# Altium schematic sheet Sheet1.SchDoc(Sheet1)
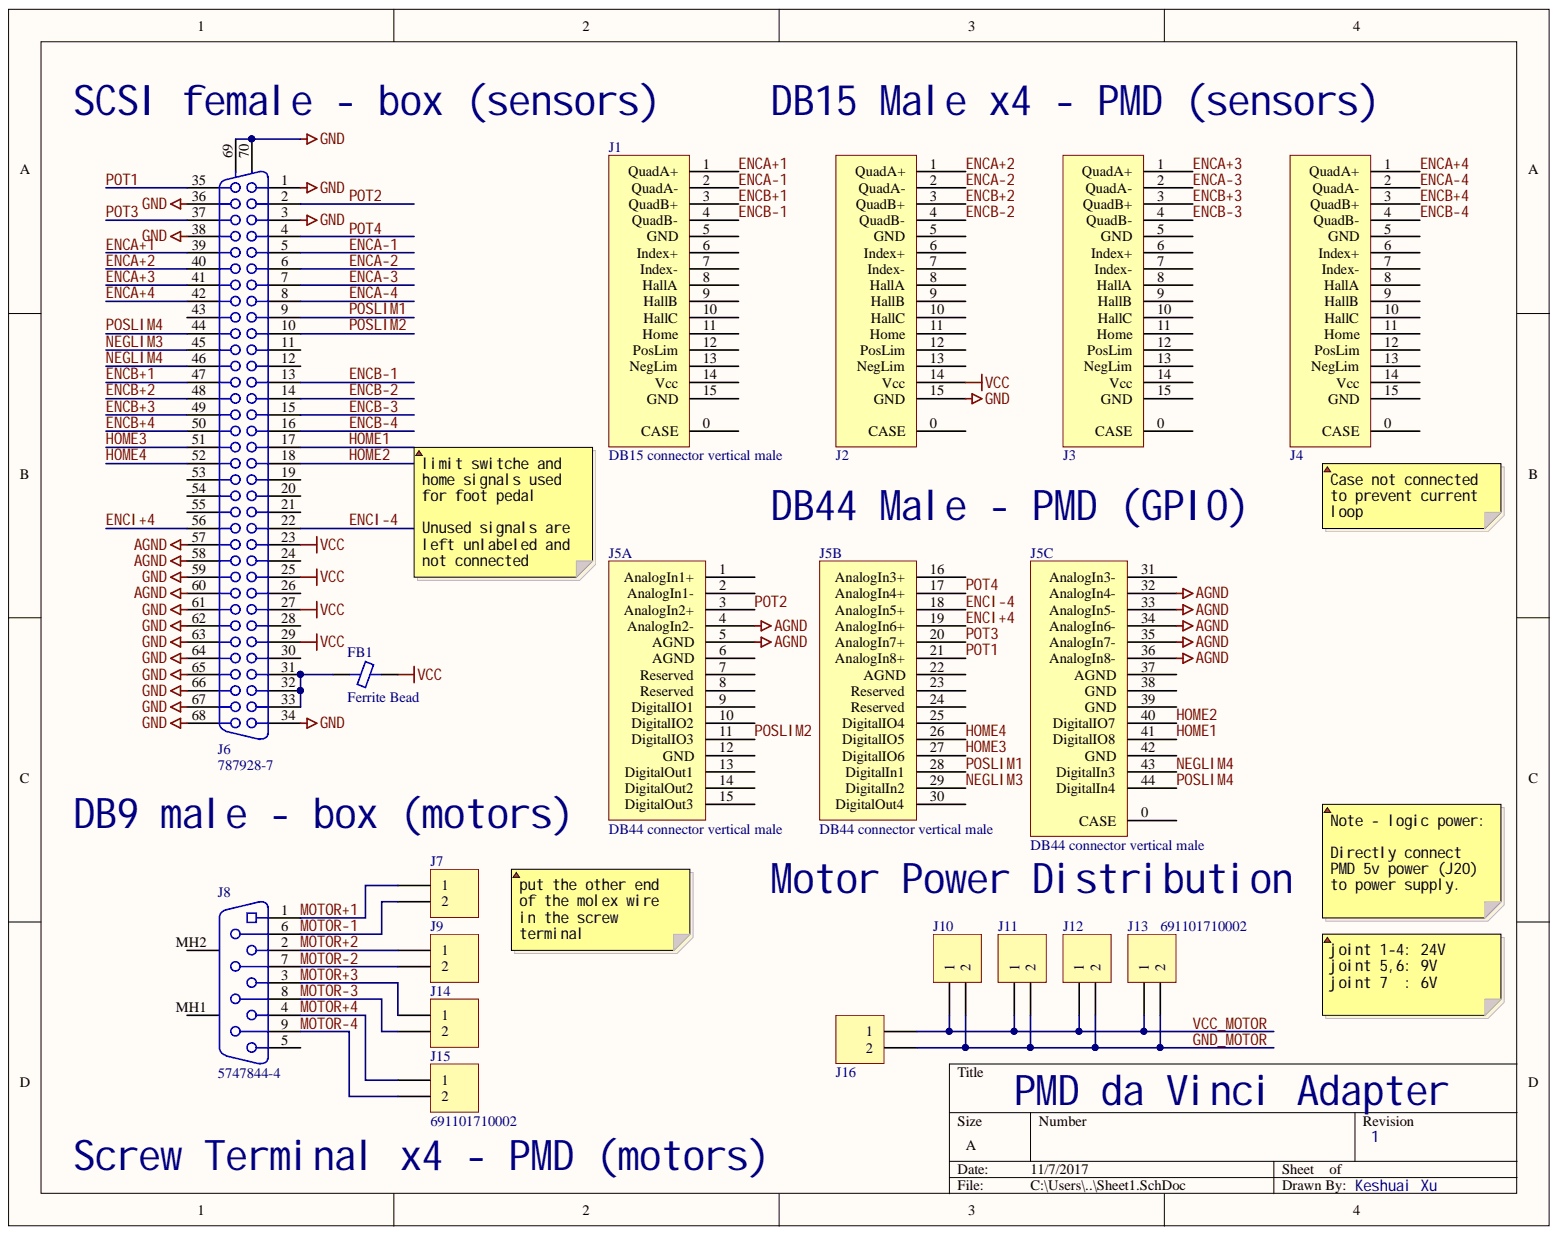
[diagram p0: Sheet1.SchDoc]

## Parts
FB1  Ferrite Bead  [IND_0603]
J1  DB15 connector vertical male  [10090928-P154XLF]
J2  DB15 connector vertical male  [10090928-P154XLF]
J3  DB15 connector vertical male  [10090928-P154XLF]
J4  DB15 connector vertical male  [10090928-P154XLF]
J5  DB44 connector vertical male  [10090928-P444XLF]  (parts A,B,C)
J6  787928-7  [787928-7]
J7  691101710002  [691101710002]
J8  5747844-4  [TE-5747844-4_V]
J9  691101710002  [691101710002]
J10  691101710002  [691101710002]
J11  691101710002  [691101710002]
J12  691101710002  [691101710002]
J13  691101710002  [691101710002]
J14  691101710002  [691101710002]
J15  691101710002  [691101710002]
J16  691101710002  [691101710002]

## Nets
AGND: J5.4(AnalogIn2-) J5.5(AGND) J5.32(AnalogIn4-) J5.33(AnalogIn5-) J5.34(AnalogIn6-) J5.35(AnalogIn7-) J5.36(AnalogIn8-) J6.57 J6.58 J6.60
GND: J2.15(GND) J6.1 J6.3 J6.34 J6.36 J6.38 J6.59 J6.61 J6.62 J6.63 J6.64 J6.65 J6.66 J6.67 J6.68 J6.69 J6.70
VCC: FB1.2 J2.14(Vcc) J6.23 J6.25 J6.27 J6.29
ENCA+1: J1.1(QuadA+) J6.39
ENCA+2: J2.1(QuadA+) J6.40
ENCA+3: J3.1(QuadA+) J6.41
ENCA+4: J4.1(QuadA+) J6.42
ENCA-1: J1.2(QuadA-) J6.5
ENCA-2: J2.2(QuadA-) J6.6
ENCA-3: J3.2(QuadA-) J6.7
ENCA-4: J4.2(QuadA-) J6.8
ENCB+1: J1.3(QuadB+) J6.47
ENCB+2: J2.3(QuadB+) J6.48
ENCB+3: J3.3(QuadB+) J6.49
ENCB+4: J4.3(QuadB+) J6.50
ENCB-1: J1.4(QuadB-) J6.13
ENCB-2: J2.4(QuadB-) J6.14
ENCB-3: J3.4(QuadB-) J6.15
ENCB-4: J4.4(QuadB-) J6.16
ENCI+4: J5.19(AnalogIn6+) J6.56
ENCI-4: J5.18(AnalogIn5+) J6.22
GND_MOTOR: J10.2 J11.2 J12.2 J13.2 J16.2
HOME1: J5.41(DigitalIO8) J6.17
HOME2: J5.40(DigitalIO7) J6.18
HOME3: J5.27(DigitalIO6) J6.51
HOME4: J5.26(DigitalIO5) J6.52
MOTOR+1: J7.1 J8.1
MOTOR+2: J8.2 J9.1
MOTOR+3: J8.3 J14.1
MOTOR+4: J8.4 J15.1
MOTOR-1: J7.2 J8.6
MOTOR-2: J8.7 J9.2
MOTOR-3: J8.8 J14.2
MOTOR-4: J8.9 J15.2
NEGLIM3: J5.29(DigitalIn2) J6.45
NEGLIM4: J5.43(DigitalIn3) J6.46
POSLIM1: J5.28(DigitalIn1) J6.9
POSLIM2: J5.11(DigitalIO3) J6.10
POSLIM4: J5.44(DigitalIn4) J6.44
POT1: J5.21(AnalogIn8+) J6.35
POT2: J5.3(AnalogIn2+) J6.2
POT3: J5.20(AnalogIn7+) J6.37
POT4: J5.17(AnalogIn4+) J6.4
VCC_MOTOR: J10.1 J11.1 J12.1 J13.1 J16.1
NetFB1_1: FB1.1 J6.31 J6.32 J6.33

Not connected: J1 0(CASE) 5(GND) 6(Index+) 7(Index-) 8(HallA) 9(HallB) 10(HallC) 11(Home) 12(PosLim) 13(NegLim) 14(Vcc) 15(GND); J2 0(CASE) 5(GND) 6(Index+) 7(Index-) 8(HallA) 9(HallB) 10(HallC) 11(Home) 12(PosLim) 13(NegLim); J3 0(CASE) 5(GND) 6(Index+) 7(Index-) 8(HallA) 9(HallB) 10(HallC) 11(Home) 12(PosLim) 13(NegLim) 14(Vcc) 15(GND); J4 0(CASE) 5(GND) 6(Index+) 7(Index-) 8(HallA) 9(HallB) 10(HallC) 11(Home) 12(PosLim) 13(NegLim) 14(Vcc) 15(GND); J5 0(CASE) 1(AnalogIn1+) 2(AnalogIn1-) 6(AGND) 7(Reserved) 8(Reserved) 9(DigitalIO1) 10(DigitalIO2) 12(GND) 13(DigitalOut1) 14(DigitalOut2) 15(DigitalOut3) 16(AnalogIn3+) 22(AGND) 23(Reserved) 24(Reserved) 25(DigitalIO4) 30(DigitalOut4) 31(AnalogIn3-) 37(AGND) 38(GND) 39(GND) 42(GND); J6 11 12 19 20 21 24 26 28 30 43 53 54 55; J8 5 MH1 MH2

## Library data of the parts
FB1 = Ferrite Chip: SMT Ferrite Chip; Library Name: Passives.SchLib; Component Kind: Standard
J1-J4 = PMD-sensor: Component Kind: Standard; Library Name: pmd_davinci_adapter.SCHLIB
J5 = PMD-GPIO: Component Kind: Standard; Library Name: pmd_davinci_adapter.SCHLIB
J6 = 787928-7: Receptacle Assembly, Shielded, Right Angle, AMPLIMITE; Positions: 68; PCB_Layout: Complies with manufacturer's recommendation.; Note: Series III; Note: With Mating Lock; Gender: Receptacle Header; DrillSize: Corresponds to nominal value.; Signal Integrity: D Subminiature; Published: 17-Jul-2002; PackageReference: 787928-7; PackageDocument: 13-Jan-2000; Orientation: Right Angle; DatasheetDocument: 13-Jan-2000; ComponentLink3URL: http://catalog.tycoelectronics.com/TE/docs/cadgeo/2/25/41522.tar.gz; ComponentLink3Description: URL Link1; ComponentLink2URL: http://ecommas.tycoelectronics.com/commerce/DocumentDelivery/DDEController?Action=showdoc&DocId=Customer+Drawing%7F787928%7FE%7Fpdf%7FEnglish%7FENG_CD_787928_E.pdf; ComponentLink2Description: Packaging Specification; ComponentLink1URL: http://www.tycoelectronics.com/; ComponentLink1Description: Manufacturer Link; Component Kind: Standard; Publisher: Altium Limited; Library Name: pmd_davinci_adapter.SCHLIB
J7 J9-J16 = 691101710002: WR-TBL Serie 101 - 5.00 mm Horizontal Entry Modular, 02p; Pitch: 5 mm; Published Date: Feb. 2017; Pin Number: 02p; Part Number: 691101710002; ComponentLink2URL: http://katalog.we-online.de/em/datasheet/6911017100xx.pdf; ComponentLink2Description: Datasheet; ComponentLink1URL: http://www.we-online.de; ComponentLink1Description: Manufacturer; Component Kind: Standard; Publisher: Wurth Elektronik; Library Name: ALTIUM-TBL_5_00_THR_5027_HORIZONTAL_ENTRY_MODULAR_W_PRESSURE_CLAMP_69150271000X-rev17a.IntLib
  J7 J9-J15: ClassName: no-clearance-check
  J16: ComponentClass: no-clearance-check
J8 = CMP-1684-00001-6: Female D-Sub Receptacle, Pitch 1.3716 mm, 9 Position, Height 12.9286 mm, -55 to 105 degC, RoHS, Tray; Manufacturer: TE Connectivity; Voltage Rating: 125 V; Rows: 2; Current Rating: 6 A; RoHSCompliant: true; REACH SVHC: No SVHC; Pins: 9; Packaging: Tray; PackageReference: 5747844-4; Package Quantity: 130; PackageDescription: Female D-Sub Receptacle, Pitch 1.3716 mm, 9 Position, Height 12.9286 mm; Mounting Technology: Through Hole; Mount: Through Hole; Min Operating Temperature: -55 degC; Max Operating Temperature: 105 degC; Library Name: Altium Content Vault; Length: 30.81 mm; Lead Pitch: 2.74 mm; Height: 12.54 mm; DatasheetVersion: Rev. P, 03/2011; Contact Plating: Gold; Contact Material: Bronze; Contact Finish: Gold; ComponentLink2URL: http://www.te.com/commerce/DocumentDelivery/DDEController?Action=srchrtrv&DocNm=5747844&DocType=Customer+Drawing&DocLang=English; ComponentLink2Description: Datasheet; ComponentLink1URL: http://www.te.com/; ComponentLink1Description: Manufacturer URL; Component Kind: Standard; Colour: Black
